# Altium schematic sheet IMU.SchDoc(IMU)
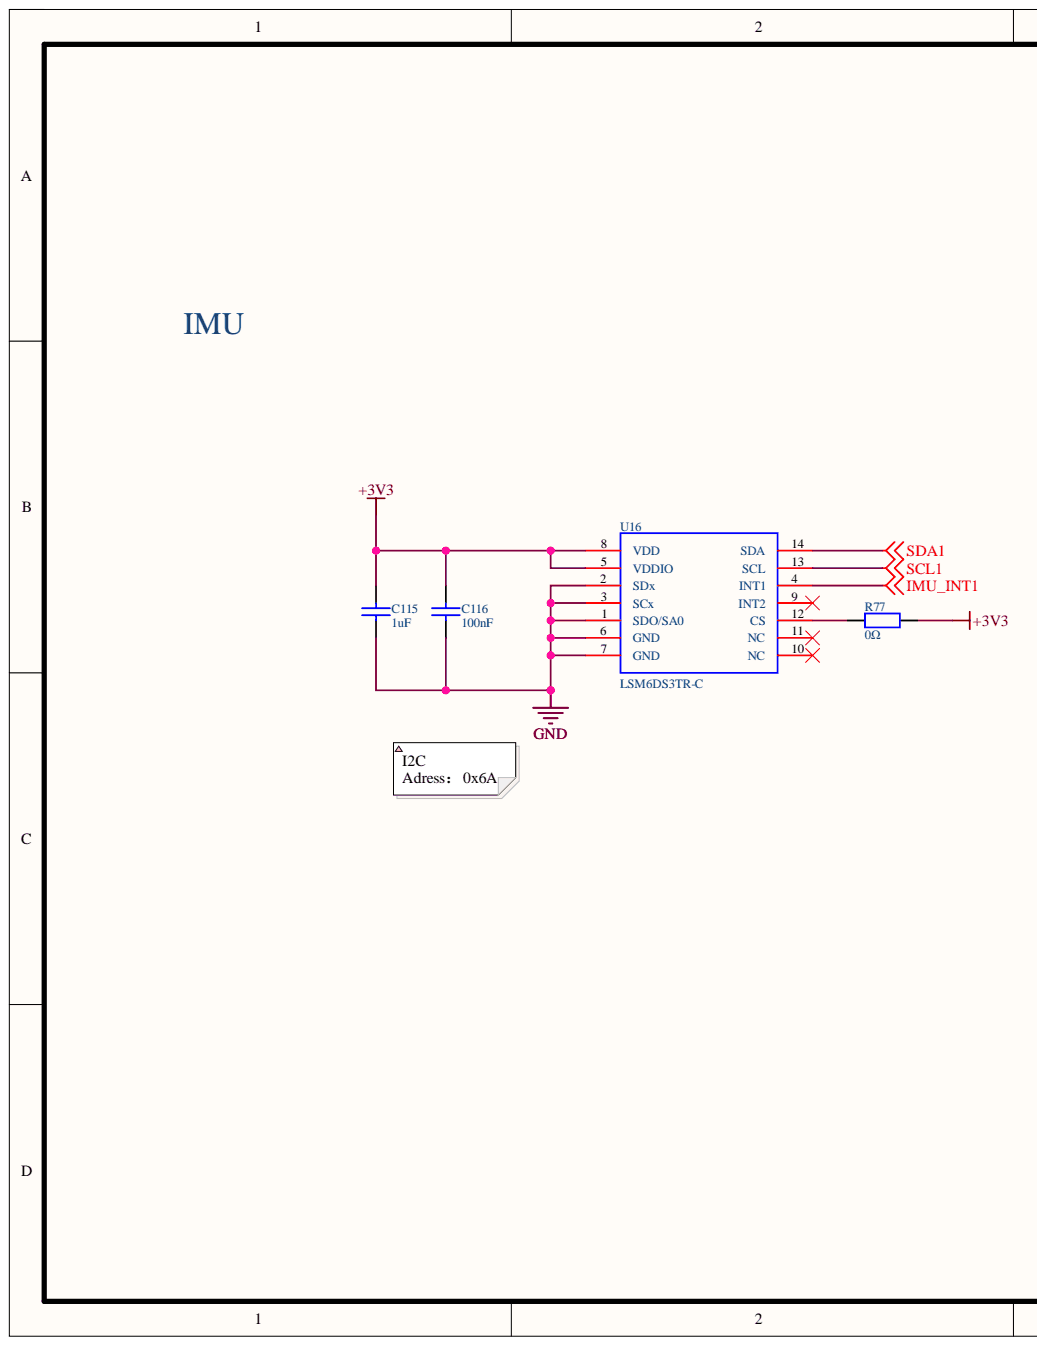
[diagram p0: IMU.SchDoc, left]
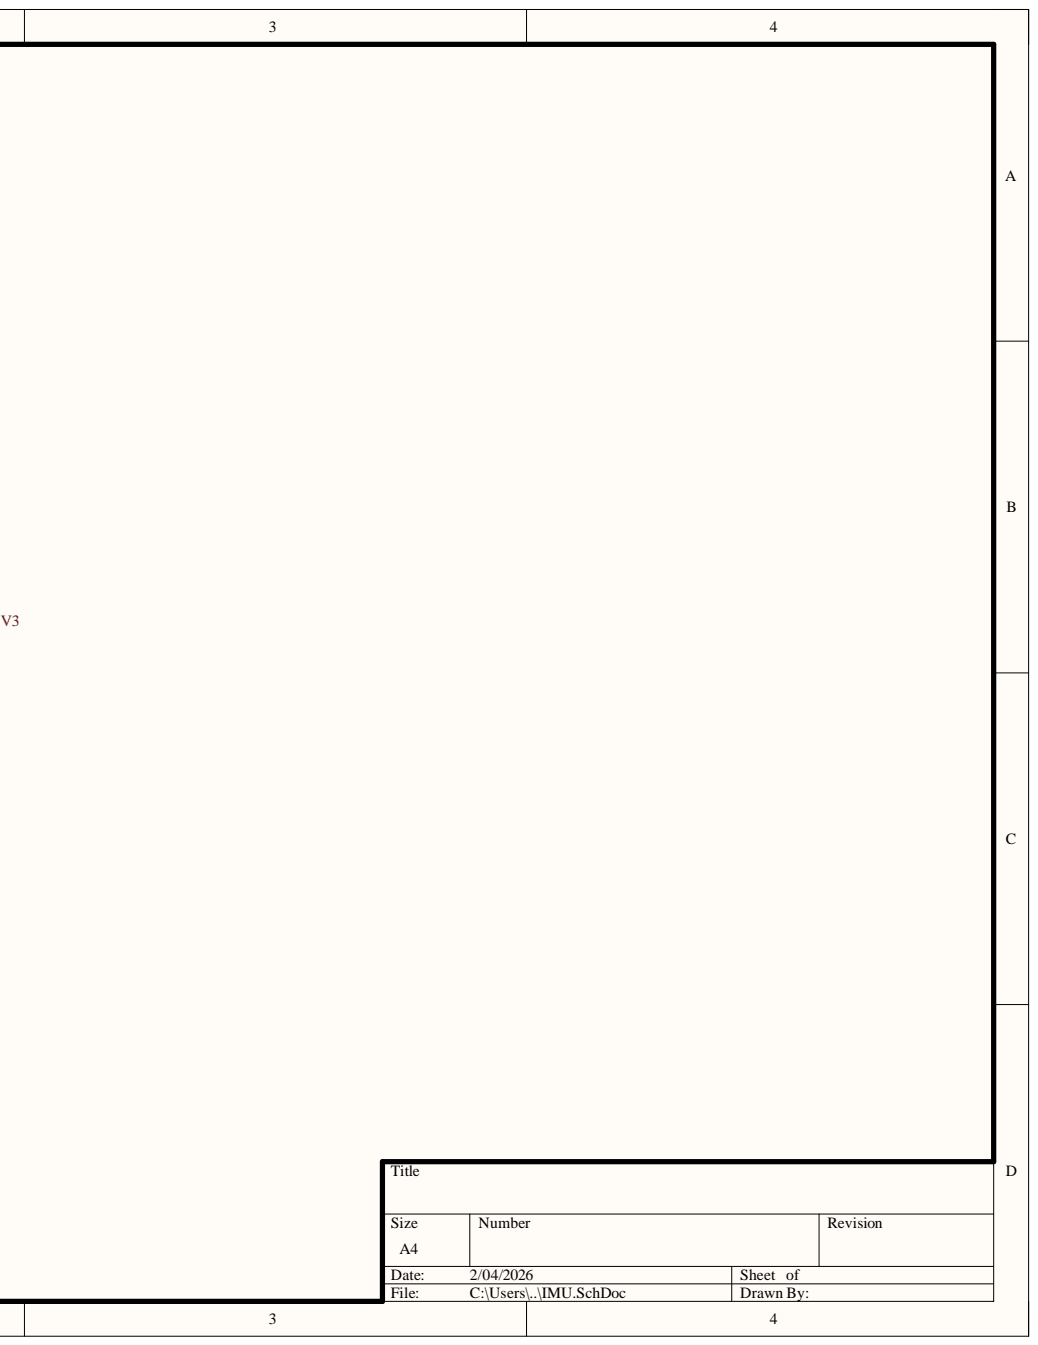
[diagram p1: IMU.SchDoc, right]

## Parts
C115  1uF  [C0402]
C116  100nF  [C0201]
R77  0Ω  [R0402]
U16  LSM6DS3TR-C  [LGA-14_L3.0-W2.5-P0.50-TL]

## Nets
+3V3: C115.1 C116.2 R77.2 U16.5(VDDIO) U16.8(VDD)
GND: C115.2 C116.1 U16.1(SDO/SA0) U16.2(SDx) U16.3(SCx) U16.6(GND) U16.7(GND)
IMU_INT1: U16.4(INT1)
SCL1: U16.13(SCL)
SDA1: U16.14(SDA)
NetR77_1: R77.1 U16.12(CS)

Not connected: U16 9(INT2) 10(NC) 11(NC)

## Library data of the parts
C115 = 0402 1uF (105) 10% 25V: 贴片电容; Component Kind: Standard; ComponentLink1Description: 供应商链接; ComponentLink1URL: http://www.szlcsc.com/product/details_53938.html; Library Name: RA8P1.SCHLIB; Package: 0402; Supplier: LC; SuppliersPartNumber: C52923
C116 = CAP-000030-01: 0201,100nF ±10% 16V，X7R; Legacy Part Number: 151-10004022; Manufacturer: muRata; Manufacturer Part Number 1: GRM033Z71C104KE14D; Dialectric: X7R; Notes: ANY EQUIVALENT; Voltage: 16V; Capacitance: 100nF; ClassName: MCU; Component Kind: Standard; ComponentLink1Description: New link; ComponentLink1URL: https://item.szlcsc.com/698285.html?fromZone=l_c__%2522catalog%2522&spm=sc.cid.xh3.zy.n___sc.gb.xh1.zy.l&lcsc_vid=FgdZAQZURgVfVlRQQQNbBAcFQVZYX1NeQ1BYX10ARFkxVlNSQ1FZUVReQVdaUjsOAxUeFF5JWAgaAglIBBsCBBcFWQIBCks%3D; Library Name: RA8P1.SCHLIB; Manufacturer 1: muRata; Manufacturer 3: AVX; Manufacturer Part Number 3: 0402YC104KAT2A; Mfg: muRata; Mfg P/N: GRM033Z71C104KE14D; Mfg Part Number: GRM033Z71C104KE14D; Package: 0402; PN: GRM033Z71C104KE14D; Supplier 1: Arrow Electronics; Supplier 2: Digi-Key; Supplier 3: Newark; Supplier 4: Mouser; Supplier Part Number 1: CC0402KRX7R8BB104; Supplier Part Number 2: 311-2077-1-ND; Supplier Part Number 3: 40AJ6370; Supplier Part Number 4: 603-CC402KRX7R8BB104; Tolerance: 10%
R77 = 0402 0Ω (0R0) 1%: 贴片电阻; Component Kind: Standard; ComponentLink1Description: 供应商链接; ComponentLink1URL: http://www.szlcsc.com/product/details_17853.html; Library Name: RA8P1.SCHLIB; Package: 0402; Supplier: LC; SuppliersPartNumber: C17168
U16 = LSM6DS3TR-C: Component Kind: Standard; Library Name: RA8P1.SCHLIB; Symbol: LSM6DS3TR-C
